# Altium schematic sheet PMIC.SchDoc
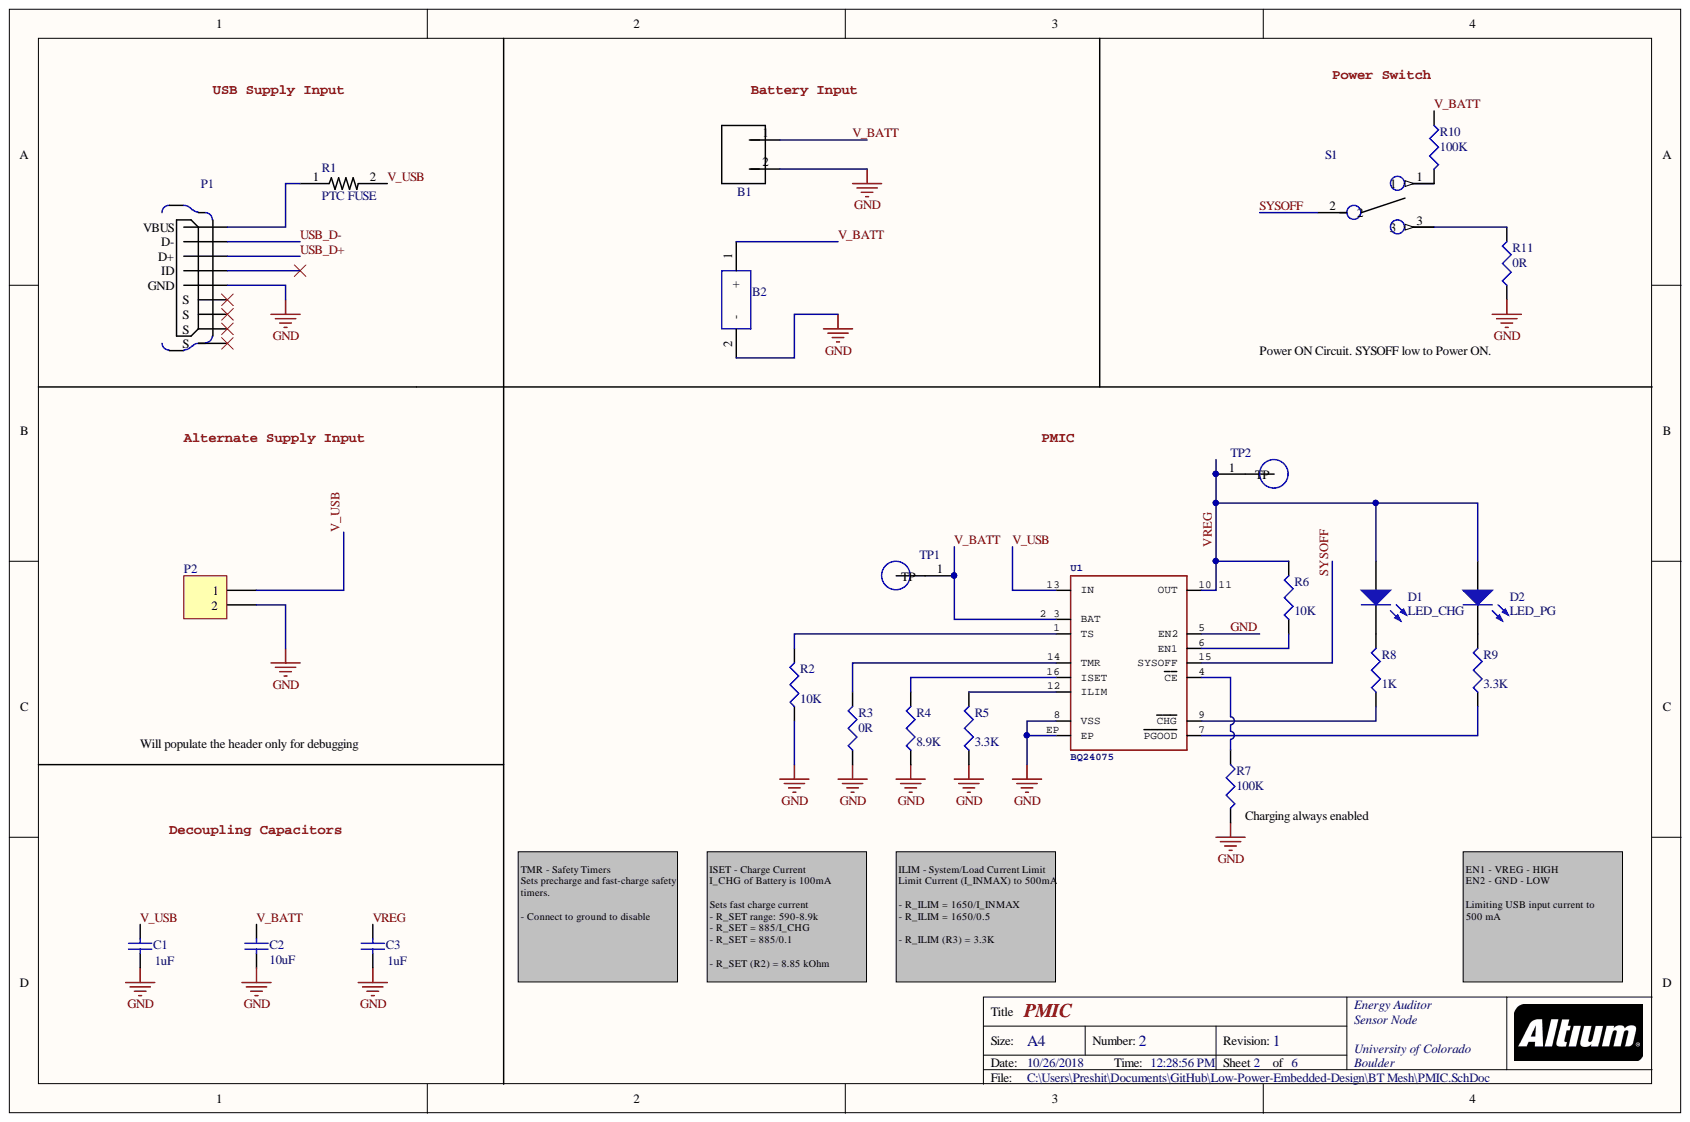
[diagram p0: PMIC.SchDoc]

## Parts
B1  S2B-PH-SM4-TB(LF)(SN)  [S2B-PH-SM4-TB(LF)(SN)]  (value not drawn)
B2  SMTU2450N-LF_BULK  [SMTU2430-LF]  (value not drawn)
C1  1uF  [1608[0603]]
C2  10uF  [1608[0603]]
C3  1uF  [1608[0603]]
D1  LED_CHG  [3.2X1.6X1.1]
D2  LED_PG  [3.2X1.6X1.1]
P1  10104111-0001LF  [FRAMATOME_10104111-0001LF]  (value not drawn)
P2  Header 2  [HDR1X2]  (value not drawn)
R1  PTC FUSE  [RESC3216X125N]
R2  10K  [1608[0603]]
R3  0R  [1608[0603]]
R4  8.9K  [1608[0603]]
R5  3.3K  [1608[0603]]
R6  10K  [1608[0603]]
R7  100K  [1608[0603]]
R8  1K  [1608[0603]]
R9  3.3K  [1608[0603]]
R10  100K  [1608[0603]]
R11  0R  [1608[0603]]
S1  AYZ0102AGRLC  [SW_AYZ0102AGRLC]  (value not drawn)
TP1  5019  [TP380X203]  (value not drawn)
TP2  5019  [TP380X203]  (value not drawn)
U1  BQ24075  [QFN50P300X300X100-17N]

## Nets
GND: B1.2 B2.2 C1.1 C2.1 C3.1 P1.5 P2.2 R2.1 R3.1 R4.1 R5.1 R7.2 R11.1 U1.5(EN2) U1.8(VSS) U1.EP
SYSOFF: S1.2 U1.15(SYSOFF)
USB_D+: P1.3(D+)
USB_D-: P1.2(D-)
V_BATT: B1.1 B2.1 C2.2 R10.1 TP1.1 U1.2 3(BAT)
V_USB: C1.2 P2.1 R1.2 U1.13(IN)
VREG: C3.2 D1.1 D2.1 R6.2 TP2.1 U1.10 11(OUT)
NetD1_2: D1.2 R8.2
NetD2_2: D2.2 R9.2
NetP1_1: P1.1 R1.1
NetR2_2: R2.2 U1.1(TS)
NetR3_2: R3.2 U1.14(TMR)
NetR4_2: R4.2 U1.16(ISET)
NetR5_2: R5.2 U1.12(ILIM)
NetR6_1: R6.1 U1.6(EN1)
NetR7_1: R7.1 U1.4(CE)
NetR8_1: R8.1 U1.9(CHG)
NetR9_1: R9.1 U1.7(PGOOD)
NetR10_2: R10.2 S1.1
NetR11_2: R11.2 S1.3

Not connected: P1 4(ID) 6(S) 7(S) 8(S) 9(S)

## Library data of the parts
B1 = S2B-PH-SM4-TB(LF)(SN): PH Series 2 Position 2 mm Pitch Surface Mount Side Entry Shrouded Header; Manufacturer: JST Sales America Inc.; Component Kind: Standard; ComponentLink1Description: Digi-Key Link; ComponentLInk1URL: https://www.digikey.com/products/en/connectors-interconnects/rectangular-connectors-headers-male-pins/314?k=S2B-PH-SM4-TB&k=&pkeyword=S2B-PH-SM4-TB&pv7=2&sf=0&quantity=&ColumnSort=0&page=1&pageSize=25; Digi-Key Part Number: 455-1749-1-ND; Library Name: S2B-PH-SM4-TB_LF__SN_.SchLib; Manufacturer Part Number: S2B-PH-SM4-TB(LF)(SN)
B2 = SMTU2450N-LF_BULK: Coin Cell Battery Holders SFC MNT FOR CR2450N; Manufacturer: RENATA; Manufacturer Part Number: SMTU2450N-LF BULK; Component Kind: Standard; ComponentLink1Description: Mouser Link; ComponentLink1URL: https://www.mouser.com/ProductDetail/Renata/SMTU2450N-LF-BULK?qs=LsPilcdHE7Gn4SDnejz9jQ%3D%3D; Library Name: SMTU2450N-LF_BULK.SchLib; Mouser Part Number: 614-SMTU2450N-LF
C1 C3 = Cap: CAP CER 1UF 16V X7R 0603; Manufacturer: Samsung Electro-Mechanics; Manufacturer Part Number: CL10B105MO8NNWC; Component Kind: Standard; ComponentLink1Description: Digi-Key Link; ComponentLink1URL: https://www.digikey.com/product-detail/en/samsung-electro-mechanics/CL10B105MO8NNWC/1276-6524-1-ND/5961383; Digi-Key Part Number: 1276-6524-1-ND; Library Name: Miscellaneous Devices.IntLib; Signal Integrity: Cap; Simulation: CAP; Value: 1uF
C2 = Cap Semi: CAP CER 10UF 10V X5R 0603; Manufacturer: Murata Electronics North America; Manufacturer Part Number: GRM188R61A106KE69D; Component Kind: Standard; ComponentLink1Description: Digi-Key Link; ComponentLink1URL: https://www.digikey.com/products/en?keywords=490-10474-1-ND; Digi-Key Part Number: 490-10474-1-ND; Library Name: Miscellaneous Devices.IntLib; Signal Integrity: Cap Semi; Simulation: CAP; Value: 10uF
D1 = LED2: Blue 470nm LED Indication - Discrete 2.85V 0603 (1608 Metric); Manufacturer: OSRAM Opto Semiconductors Inc.; Manufacturer Part Number: LB Q39G-L2OO-35-1; Component Kind: Standard; ComponentLink1Description: Digi-Key Link; ComponentLink1URL: https://www.digikey.com/product-detail/en/osram-opto-semiconductors-inc/LB-Q39G-L2OO-35-1/475-2816-1-ND/2176355; Digi-Key Part Number: 475-2816-1-ND; Library Name: Miscellaneous Devices.IntLib; Simulation: LED2
D2 = LED2: LED GREEN DIFFUSED 0603 SMD; Manufacturer: OSRAM Opto Semiconductors Inc.; Manufacturer Part Number: LG L29K-F2J1-24-Z; Component Kind: Standard; ComponentLink1Description: Digi-Key Link; ComponentLink1URL: https://www.digikey.com/product-detail/en/osram-opto-semiconductors-inc/LG-L29K-F2J1-24-Z/475-3118-1-ND/4899549; Digi-Key Part Number: 475-3118-1-ND; Library Name: Miscellaneous Devices.IntLib; Simulation: LED2
P1 = 10104111-0001LF: Micro Usb, 2.0 Type Ab, Receptacle, Th; Manufacturer: Amphenol FCI; Component Kind: Standard; ComponentLink1Description: Digi-Key Link; ComponentLink1URL: https://www.digikey.com/product-detail/en/amphenol-fci/10104111-0001LF/609-4053-1-ND/2350359?utm_source=snapeda&utm_medium=aggregator&utm_campaign=symbol; Digi-Key Part Number: 609-4053-1-ND; Library Name: USB-connector.SchLib; Manufacturer Part Number: 10104111-0001LF
P2 = Header 2: Header, 2-Pin; Component Kind: Standard; Library Name: Miscellaneous Connectors.IntLib; Signal Integrity: Connector
R1 = 0ZCA0075FF2G: PTC RESET FUSE 6V 500MA 1206; Manufacturer: Bel Fuse; Manufacturer Part Number: Littelfuse Inc.; Component Kind: Standard; ComponentLink1Description: Digi-Key Link; ComponentLink1URL: https://www.digikey.com/product-detail/en/littelfuse-inc/1206L050YR/F2112CT-ND/455721; Digi-Key Part Number: F2112CT-ND; Library Name: PTC-1206.SchLib
R2 R6 = Res3: RES SMD 10K OHM 1% 1/8W 0603; Manufacturer: Vishay Beyschlag; Manufacturer Part Number: MCT06030C1002FP500; Component Kind: Standard; ComponentLink1Description: Digi-Key Link; ComponentLink1URL: https://www.digikey.com/products/en?keywords=MCT0603-10.0K-CFCT-ND; Digi-Key Part Number: MCT0603-10.0K-CFCT-ND; Library Name: Miscellaneous Devices.IntLib; Signal Integrity: Res; Simulation: RESISTOR; Value: 10K
R3 R11 = Res3: RES 0 OHM JUMPER 1/8W 0603; Manufacturer: Vishay Beyschlag; Manufacturer Part Number: MCT0603MZ0000ZP500; Component Kind: Standard; ComponentLink1Description: Digi-Key Link; ComponentLink1URL: https://www.digikey.com/product-detail/en/vishay-beyschlag/MCT0603MZ0000ZP500/749-1745-1-ND/7348053; Digi-Key Part Number: 749-1745-1-ND; Library Name: Miscellaneous Devices.IntLib; Signal Integrity: Res; Simulation: RESISTOR; Value: 0R
R4 = Res3: RES SMD 8.87KOHM 0.5% 1/10W 0603; Manufacturer: Yageo; Manufacturer Part Number: RT0603DRD078K87L; Component Kind: Standard; ComponentLink1Description: Digi-Key Link; ComponentLink1URL: https://www.digikey.com/product-detail/en/yageo/RT0603DRD078K87L/311-8.87KDCT-ND/1035893; Digi-Key Part Number: 311-8.87KDCT-ND; Library Name: Miscellaneous Devices.IntLib; Signal Integrity: Res; Simulation: RESISTOR; Value: 8.9K
R5 R9 = Res3: RES SMD 3.3K OHM 5% 1/10W 0603; Manufacturer: Panasonic Electronic Components; Manufacturer Part Number: ERJ-3GEYJ332V; Component Kind: Standard; ComponentLink1Description: Digi-Key Link; ComponentLink1URL: https://www.digikey.com/product-detail/en/panasonic-electronic-components/ERJ-3GEYJ332V/P3.3KGCT-ND/135146; Digi-Key Part Number: P3.3KGCT-ND; Library Name: Miscellaneous Devices.IntLib; Signal Integrity: Res; Simulation: RESISTOR; Value: 3.3K
R7 R10 = Res3: RES SMD 100K OHM 0.1% 1/10W 0603; Manufacturer: Susumu; Manufacturer Part Number: RG1608P-104-B-T5; Component Kind: Standard; ComponentLink1Description: Digi-Key Link; ComponentLink1URL: https://www.digikey.com/products/en/resistors/chip-resistor-surface-mount/52?k=RG1608P-104-B-T5&k=&pkeyword=RG1608P-104-B-T5&pv7=2&sf=0&quantity=&ColumnSort=0&page=1&pageSize=25; Digi-Key Part Number: RG16P100KBCT-ND; Library Name: Miscellaneous Devices.IntLib; Signal Integrity: Res; Simulation: RESISTOR; Value: 100K
R8 = Res3: RES SMD 1K OHM 0.1% 1/10W 0603; Manufacturer: Yageo; Manufacturer Part Number: RT0603BRD071KL; Component Kind: Standard; ComponentLink1Description: Digi-Key Link; ComponentLink1URL: https://www.digikey.com/product-detail/en/yageo/RT0603BRD071KL/YAG1237CT-ND/4340590; Digi-Key Part Number: YAG1237CT-ND; Library Name: Miscellaneous Devices.IntLib; Signal Integrity: Res; Simulation: RESISTOR; Value: 1K
S1 = AYZ0102AGRLC: SWITCH SLIDE SPDT 100MA 12V; Manufacturer: C&K; Component Kind: Standard; ComponentLink1Description: Digi-Key Link; ComponentLink1URL: https://www.digikey.com/products/en/switches/slide-switches/213?k=AYZ0102AGRLC&k=&pkeyword=AYZ0102AGRLC&pv7=2&sf=0&quantity=&ColumnSort=0&page=1&pageSize=25; Digi-Key Part Number: 401-2012-1-ND; Library Name: AYZ0102AGRLC.SchLib; Manufacturer Part Number: AYZ0102AGRLC
TP1 TP2 = 5019: Phosphor Bronze Contact Miniature Silver Plated Surface Mount Test Points; Manufacturer: Keystone; Component Kind: Standard; ComponentLink1Description: Digi-Key Link; ComponentLink1URL: https://www.digikey.com/product-detail/en/keystone-electronics/5019/36-5019CT-ND/3907344?utm_source=snapeda&utm_medium=aggregator&utm_campaign=symbol; Digi-Key Part Number: 36-5019CT-ND; Library Name: 5019.IntLib; Manufacturer Part Number: 5019
U1 = BQ24075: IC LI-ION CHARGE MGMT 16-QFN; Manufacturer: Texas Instruments; Manufacturer Part Number: BQ24075RGTR; Component Kind: Standard; ComponentLink1Description: Digi-Key Link; ComponentLink1URL: https://www.digikey.com/products/en/integrated-circuits-ics/pmic-battery-chargers/781?k=BQ24075RGTR&k=&pkeyword=BQ24075RGTR&pv7=2&sf=0&quantity=&ColumnSort=0&page=1&pageSize=25; Digi-Key Part Number: 296-38874-1-ND; Library Name: SparkFun-PowerIC.IntLib
